# Altium schematic sheet Gate Driver.SchDoc
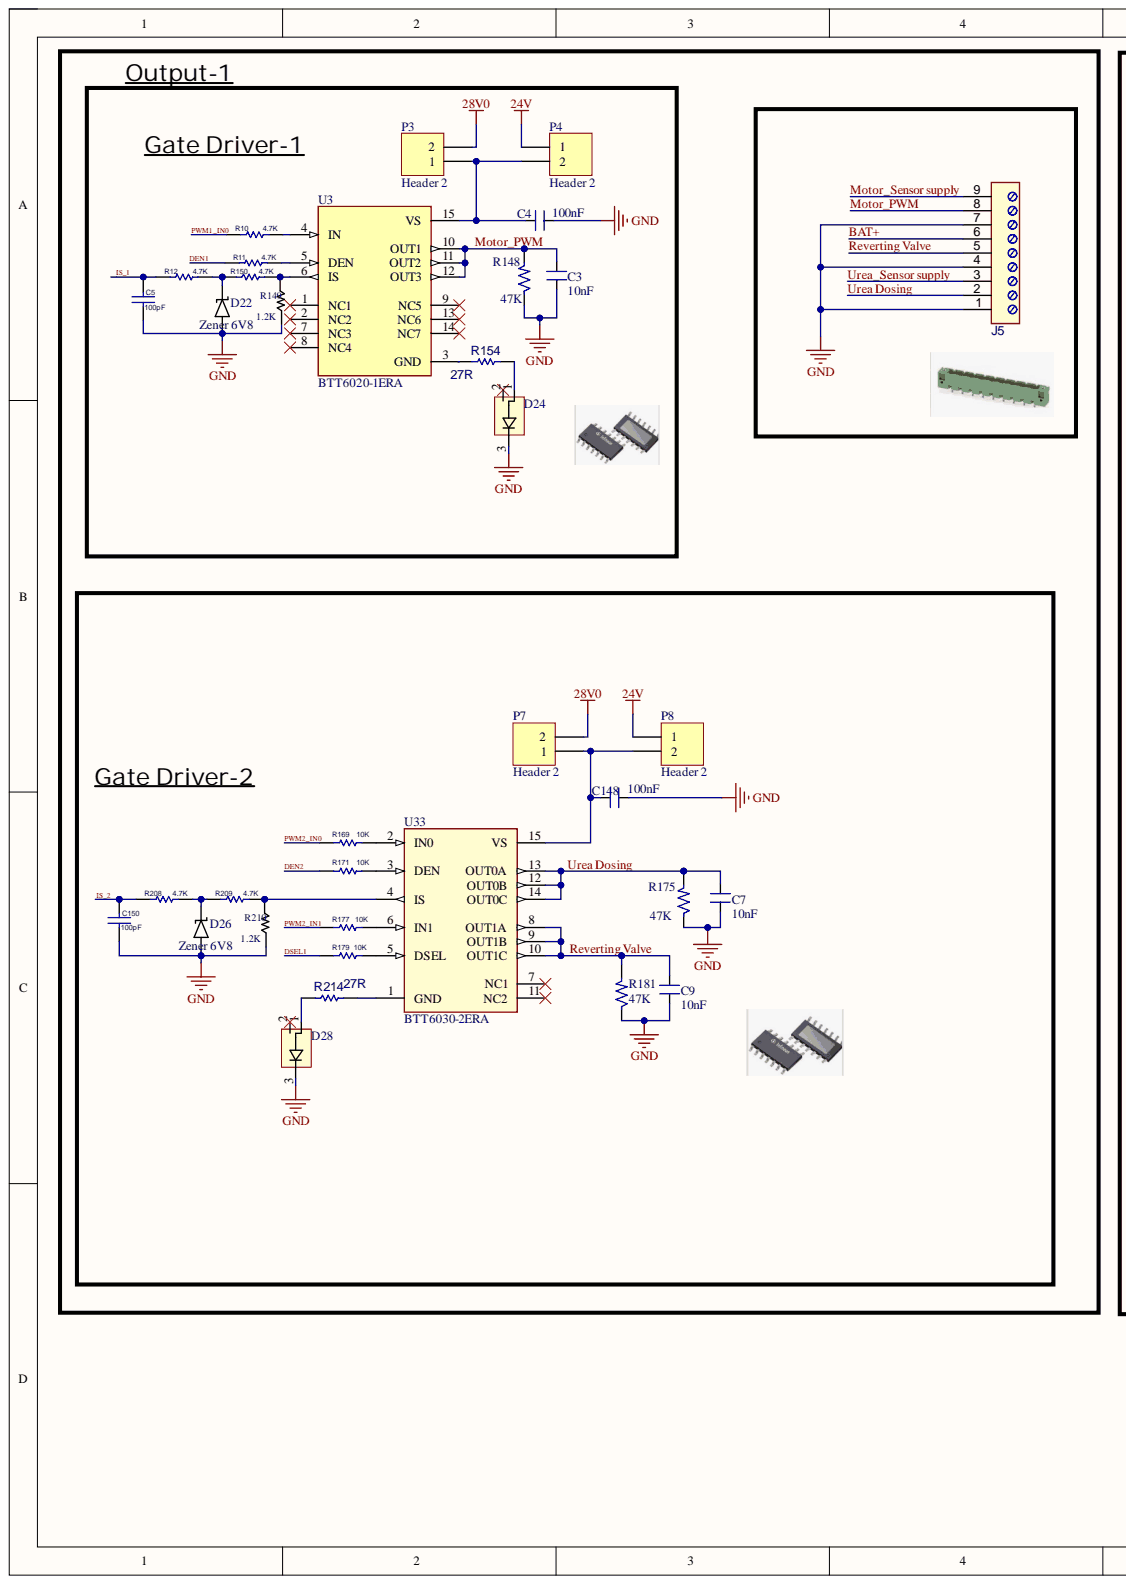
[diagram p0: Gate Driver.SchDoc, left]
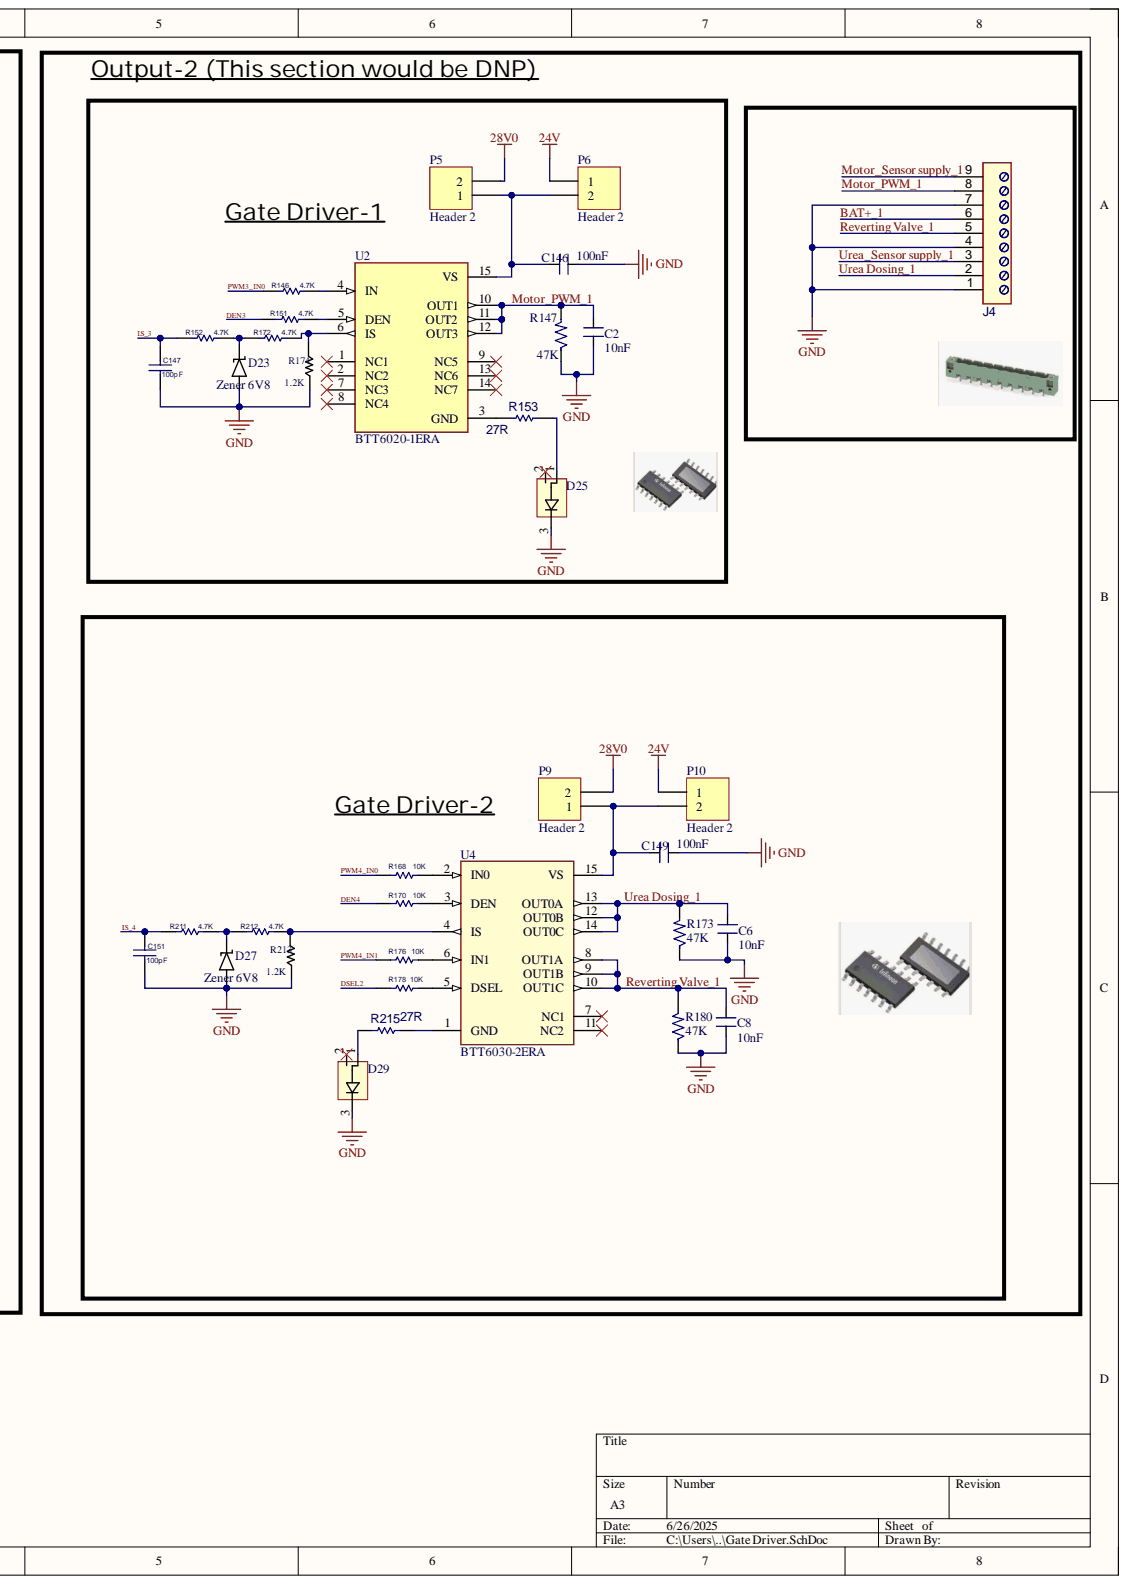
[diagram p1: Gate Driver.SchDoc, right]

## Parts
C2  10nF  [CAP_0201]
C3  10nF  [CAP_0201]
C4  100nF  [CAP_0201]
C5  100pF  [CAP-0603]
C6  10nF  [CAP_0201]
C7  10nF  [CAP_0201]
C8  10nF  [CAP_0201]
C9  10nF  [CAP_0201]
C146  100nF  [CAP_0201]
C147  100pF  [CAP-0603]
C148  100nF  [CAP_0201]
C149  100nF  [CAP_0201]
C150  100pF  [CAP-0603]
C151  100pF  [CAP-0603]
D22  Zener 6V8  [SOD0-DIODE]
D23  Zener 6V8  [SOD0-DIODE]
D24  Diode-BAS21  [SOT91P240X120-3N]  (value not drawn)
D25  Diode-BAS21  [SOT91P240X120-3N]  (value not drawn)
D26  Zener 6V8  [SOD0-DIODE]
D27  Zener 6V8  [SOD0-DIODE]
D28  Diode-BAS21  [SOT91P240X120-3N]  (value not drawn)
D29  Diode-BAS21  [SOT91P240X120-3N]  (value not drawn)
J4  1829222  [282858-9]  (value not drawn)
J5  1829222  [282858-9]  (value not drawn)
P3  Header 2  [HDR1X2]
P4  Header 2  [HDR1X2]
P5  Header 2  [HDR1X2]
P6  Header 2  [HDR1X2]
P7  Header 2  [HDR1X2]
P8  Header 2  [HDR1X2]
P9  Header 2  [HDR1X2]
P10  Header 2  [HDR1X2]
R10  4.7k  [RES-0603]
R11  4.7k  [RES-0603]
R12  4.7k  [RES-0603]
R146  4.7k  [RES-0603]
R147  47K  [RES_0402]
R148  47K  [RES_0402]
R149  1.2K  [RES 1206]
R150  4.7k  [RES-0603]
R151  4.7k  [RES-0603]
R152  4.7k  [RES-0603]
R153  27R  [RES_0805]
R154  27R  [RES_0805]
R168  10K  [RES-0603]
R169  10K  [RES-0603]
R170  10K  [RES-0603]
R171  10K  [RES-0603]
R172  4.7k  [RES-0603]
R173  47K  [RES_0402]
R174  1.2K  [RES 1206]
R175  47K  [RES_0402]
R176  10K  [RES-0603]
R177  10K  [RES-0603]
R178  10K  [RES-0603]
R179  10K  [RES-0603]
R180  47K  [RES_0402]
R181  47K  [RES_0402]
R208  4.7k  [RES-0603]
R209  4.7k  [RES-0603]
R210  1.2K  [RES 1206]
R211  4.7k  [RES-0603]
R212  4.7k  [RES-0603]
R213  1.2K  [RES 1206]
R214  27R  [RES_0805]  (value not drawn)
R215  27R  [RES_0805]  (value not drawn)
U2  BTT6020-1ERA  [SOIC127P600X115-15N-V1]
U3  BTT6020-1ERA  [SOIC127P600X115-15N-V1]
U4  BTT6030-2ERA  [SOIC127P600X115-15N-V1]
U33  BTT6030-2ERA  [SOIC127P600X115-15N-V1]

## Nets
24V: P4.1 P6.1 P8.1 P10.1
28V0: P3.2 P5.2 P7.2 P9.2
GND: C2.1 C3.1 C4.1 C5.2 C6.1 C7.1 C8.1 C9.1 C146.1 C147.2 C148.1 C149.1 C150.2 C151.2 D23.1 D24.3 D25.3 D26.1 D27.1 D28.3 D29.3 J4.1 J4.4 J4.7 J5.1 J5.4 J5.7 R147.1 R148.1 R173.1 R174.1 R175.1 R180.1 R181.1 R210.1 R213.1
BAT+: J5.6
BAT+_1: J4.6
DEN1: R11.2
DEN2: R171.2
DEN3: R151.2
DEN4: R170.2
DSEL1: R179.2
DSEL2: R178.2
IS_1: C5.1 R12.2
IS_2: C150.1 R208.2
IS_3: C147.1 R152.2
IS_4: C151.1 R211.2
Motor_PWM: C3.2 J5.8 R148.2 U3.10(OUT1) U3.11(OUT2) U3.12(OUT3)
Motor_PWM_1: C2.2 J4.8 R147.2 U2.10(OUT1) U2.11(OUT2) U2.12(OUT3)
Motor_Sensor supply: J5.9
Motor_Sensor supply_1: J4.9
PWM1_IN0: R10.2
PWM2_IN0: R169.2
PWM2_IN1: R177.2
PWM3_IN0: R146.2
PWM4_IN0: R168.2
PWM4_IN1: R176.2
Reverting Valve: C9.2 J5.5 R181.2 U33.8(OUT1A) U33.9(OUT1B) U33.10(OUT1C)
Reverting Valve_1: C8.2 J4.5 R180.2 U4.8(OUT1A) U4.9(OUT1B) U4.10(OUT1C)
Urea Dosing: C7.2 J5.2 R175.2 U33.12(OUT0B) U33.13(OUT0A) U33.14(OUT0C)
Urea Dosing_1: C6.2 J4.2 R173.2 U4.12(OUT0B) U4.13(OUT0A) U4.14(OUT0C)
Urea_Sensor supply: J5.3
Urea_Sensor supply_1: J4.3
NetC4_2: C4.2 P3.1 P4.2 U3.15(VS)
NetC146_2: C146.2 P5.1 P6.2 U2.15(VS)
NetC148_2: C148.2 P7.1 P8.2 U33.15(VS)
NetC149_2: C149.2 P9.1 P10.2 U4.15(VS)
NetD22_2: D22.2 R12.1 R150.2
NetD23_2: D23.2 R152.1 R172.2
NetD24_1: D24.1 R154.2
NetD25_1: D25.1 R153.2
NetD26_2: D26.2 R208.1 R209.2
NetD27_2: D27.2 R211.1 R212.2
NetD28_1: D28.1 R214.1
NetD29_1: D29.1 R215.1
NetR10_1: R10.1 U3.4(IN)
NetR11_1: R11.1 U3.5(DEN)
NetR146_1: R146.1 U2.4(IN)
NetR149_2: R149.2 R150.1 U3.6(IS)
NetR151_1: R151.1 U2.5(DEN)
NetR153_1: R153.1 U2.3(GND)
NetR154_1: R154.1 U3.3(GND)
NetR168_1: R168.1 U4.2(IN0)
NetR169_1: R169.1 U33.2(IN0)
NetR170_1: R170.1 U4.3(DEN)
NetR171_1: R171.1 U33.3(DEN)
NetR172_1: R172.1 R174.2 U2.6(IS)
NetR176_1: R176.1 U4.6(IN1)
NetR177_1: R177.1 U33.6(IN1)
NetR178_1: R178.1 U4.5(DSEL)
NetR179_1: R179.1 U33.5(DSEL)
NetR209_1: R209.1 R210.2 U33.4(IS)
NetR213_2: R213.2 U4.4(IS)
NetR214_2: R214.2 U33.1(GND)
NetR215_2: R215.2 U4.1(GND)

Not connected: D22 1; D24 2; D25 2; D28 2; D29 2; R149 1; R212 1; U2 1(NC1) 2(NC2) 7(NC3) 8(NC4) 9(NC5) 13(NC6) 14(NC7); U3 1(NC1) 2(NC2) 7(NC3) 8(NC4) 9(NC5) 13(NC6) 14(NC7); U4 7(NC1) 11(NC2); U33 7(NC1) 11(NC2)

## Library data of the parts
C2-C4 C6-C9 C146 C148 C149 = CAP_10nF-10V-10%-0201: 10000pF ±10% 10V Ceramic Capacitor X7R 0201 (0603 Metric); Manufacturer: TDK Corporation; Manufacturer Part Number: CGA1A2X7R1A103K030BA; Case/Package: 0201; Component Kind: Standard; Supplier-1: Digikey; Library Name: EsteemPCB_COB_Course_intlib.IntLib
C5 C147 C150 C151 = GRM155R71C104KA88D_1: CAP CER 100PF 50V C0G/NPO 0603; Manufacturer: YAGEO; Part Number: CC0603JRNPO9BN101; Component Kind: Standard; Signal Integrity: Cap; Simulation: Cap; Value: 100pF; Voltage: 50V; Library Name: GENSET CONTROLLER BETA V1.01.IntLib
D22 D23 D26 D27 = DIODE: Zener 6V8 SMD-SOD0; Component Kind: Standard; Library Name: Nulife Common.SchLib
D24 D25 D28 D29 = Diode-BAS21: BTT6020-1ERA SOT23; Component Kind: Standard; Library Name: Nulife Common.SchLib
J4 J5 = (no symbol name): TERM BLOCK HDR 9POS VERT 7.62MM; Manufacturer: Phoenix Contact; Component Kind: Standard; Part Number: 1829222
P3-P10 = Header 2: Header, 2-Pin; Component Kind: Standard; LatestRevisionDate: 17-Jul-2002; LatestRevisionNote: Re-released for DXP Platform.; Library Name: Miscellaneous Connectors.IntLib; Signal Integrity: Connector; Publisher: Altium Limited
R10-R12 R146 R150-R152 R168-R172 R176-R179 R208 R209 R211 R212 = RES-2_14: RES SMD 10K OHM 1% 1/10W 0603; Manufacturer: YAGEO; Part Number: AC0603FR-0710KL; Power Rating: 100mW; Component Kind: Standard; Package: 0603; Tolerance: ±1%; Library Name: GENSET CONTROLLER BETA V01.SCHLIB
  R10-R12 R146 R150-R152 R172 R208 R209 R211 R212: Value: 4.7K
  R168-R171 R176-R179: Value: 10K
R147 R148 R173 R175 R180 R181 = RES_47K-0.1W-1%-0402: 47 kOhms ±1% 0.1W, 1/10W Chip Resistor 0402 (1005 Metric) Automotive AEC-Q200 Thick Film; Manufacturer: Panasonic Electronic Components; Manufacturer Part Number: ERJ-2RKF4702X; Case/Package: 0402; Component Kind: Standard; Supplier-1: Digikey; Library Name: EsteemPCB_COB_Course_intlib.IntLib
R149 R174 R210 R213 = TBD: generic1206; Component Kind: Standard; Library Name: Nulife Common.SchLib
R153 R154 R214 R215 = RES_27R_5%_500mW: Thick Film Resistors - SMD CRGS0805 5% 27R, CRGS0805J27R; Manufacturer: NA; Tolerance: ±1%; Value: DNP; Case/Package: 0603; Component Kind: Standard; Part Number: NA; Library Name: GENSET CONTROLLER BETA V1.1.SCHLIB
U2 U3 = BTT6020-1ERA: BTT6020-1ERA   PG-TDSO-14; Component Kind: Standard; Library Name: Nulife Common.SchLib
U4 U33 = BTT6030-2ERA: Dual Channel Smart High-Side Power Switch; Manufacturer: Infineon Technologies; Component Kind: Standard; Library Name: BTT6030-2ERA.SchLib; Manufacturer Order Number: BTT6030-2ERA; PackageReference: PG-TDSO-14-31
